# Altium schematic sheet MCU.SchDoc(MCU)
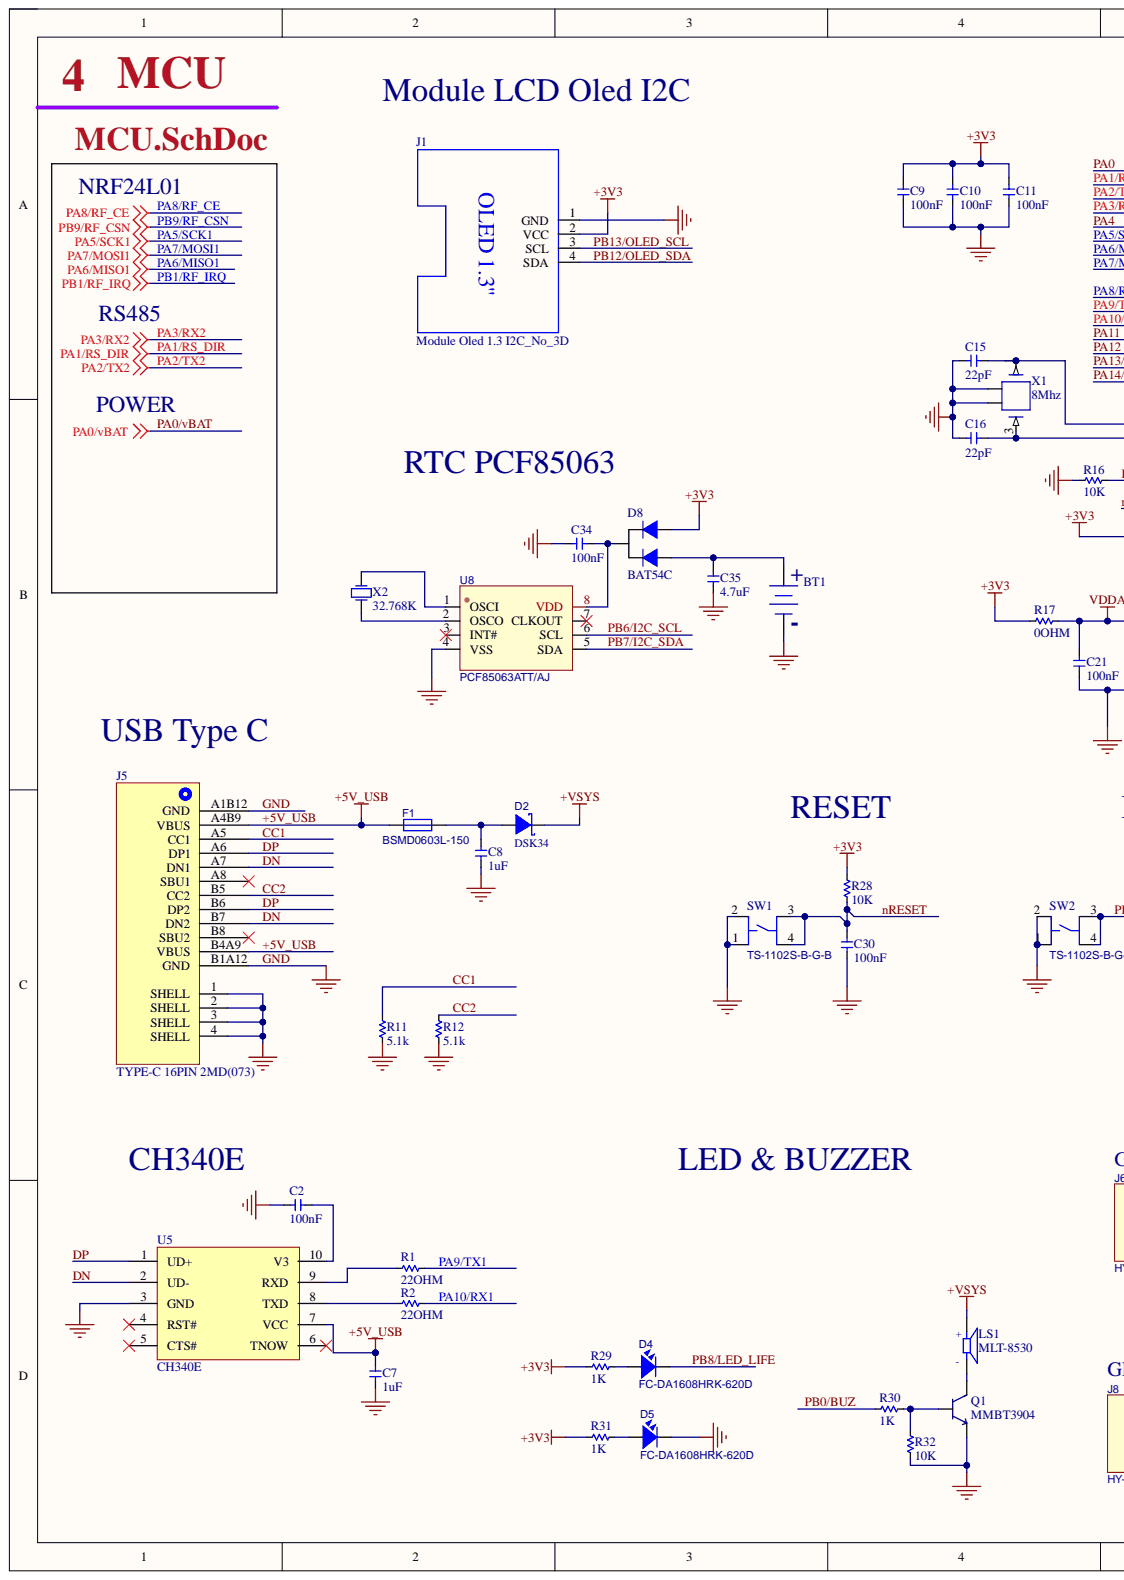
[diagram p0: MCU.SchDoc, left]
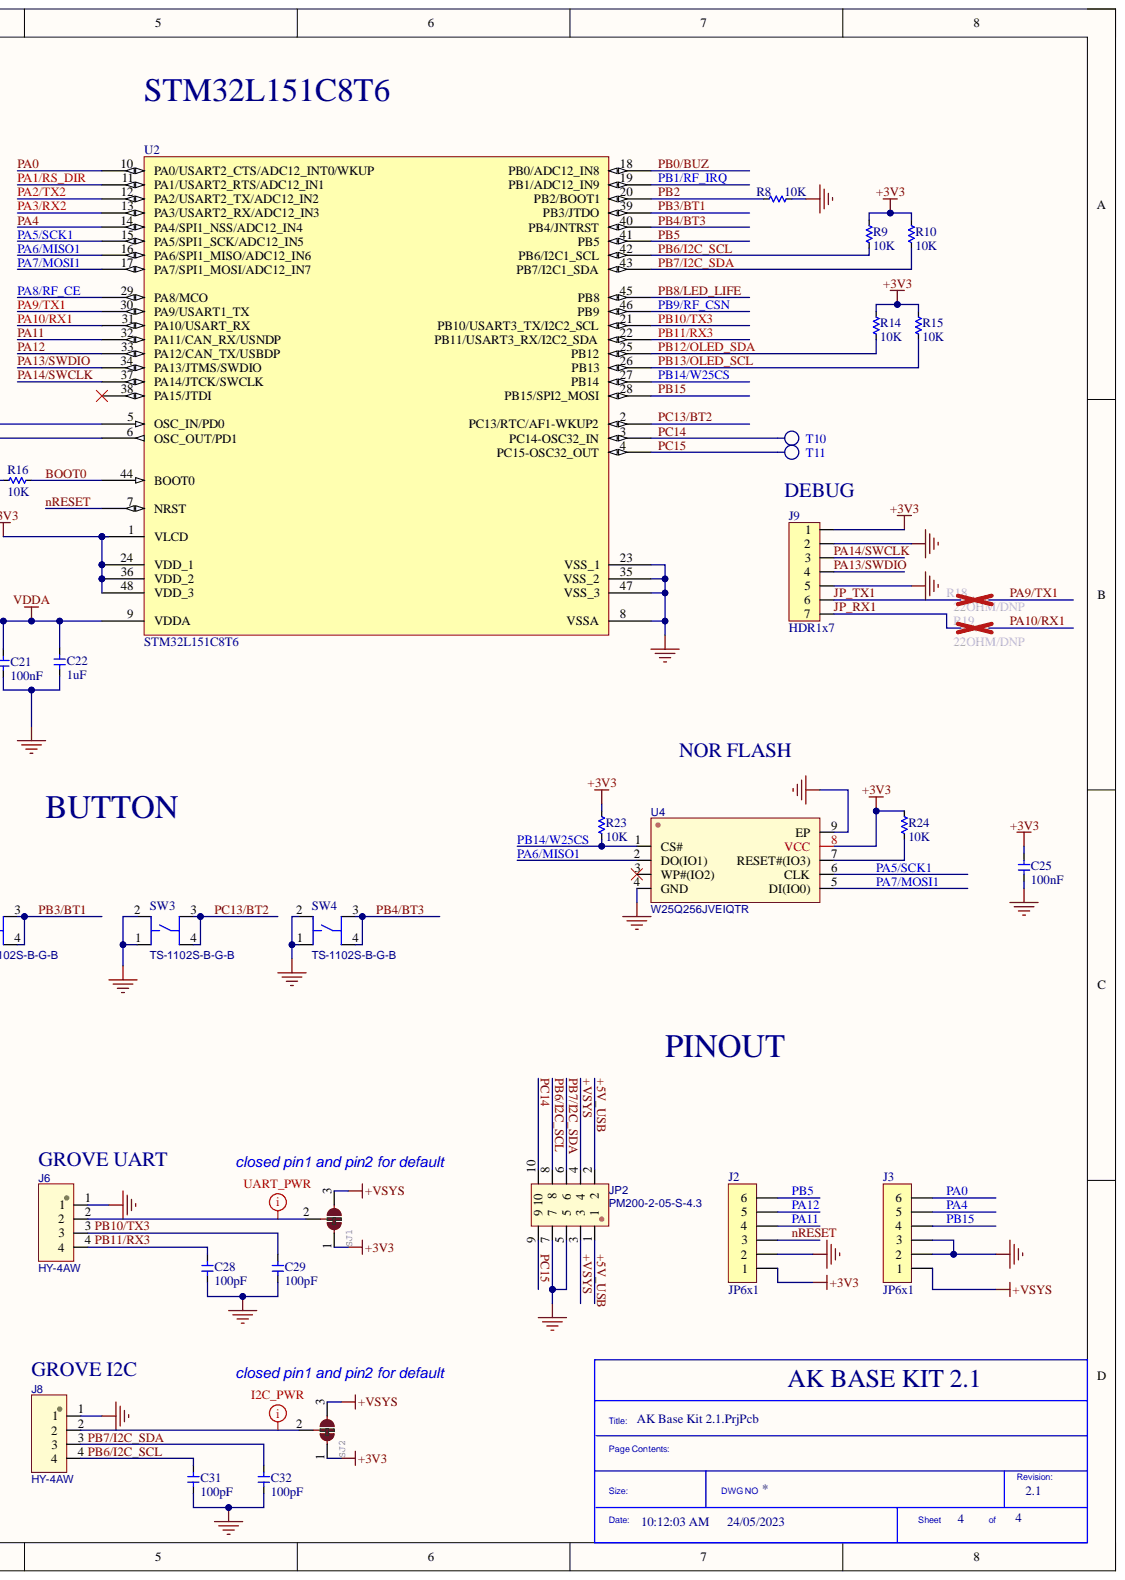
[diagram p1: MCU.SchDoc, right]

## Parts
BT1  MY-1220-03  [Batt CR1220]  (value not drawn)
C2  100nF  [C_0402]
C7  1uF  [C_0603]
C8  1uF  [C_0603]
C9  100nF  [C_0402]
C10  100nF  [C_0402]
C11  100nF  [C_0402]
C15  22pF  [C_0402]
C16  22pF  [C_0402]
C21  100nF  [C_0402]
C22  1uF  [C_0603]
C25  100nF  [C_0402]
C28  100pF  [C_0402]
C29  100pF  [C_0402]
C30  100nF  [C_0402]
C31  100pF  [C_0402]
C32  100pF  [C_0402]
C34  100nF  [C_0402]
C35  4.7uF  [C_0603]
D2  DSK34  [SOD-123_L2.8-W1.8-LS3.7-RD]
D4  FC-DA1608HRK-620D  [LED0603-RD_RED]
D5  FC-DA1608HRK-620D  [LED0603-RD_RED]
D8  BAT54C  [SOT-23-3_L2.9-W1.6-P1.90-LS2.8-BR]
F1  BSMD0603L-150  [F0603]
J1  Module Oled 1.3 I2C_No_3D  [Module Oled 1.3 - No 3D]
J2  JP6x1  [JP6-D1T]  (no BOM)
J3  JP6x1  [JP6-D1T]  (no BOM)
J5  TYPE-C 16PIN 2MD(073)  [USB-C-SMD_TYPE-C16PIN]
J6  HY-4AW  [CONN-TH_4P-P2.00_HY-4AW]
J8  HY-4AW  [CONN-TH_4P-P2.00_HY-4AW]
J9  HDR1x7  [HDR1X7H]
JP2  PM200-2-05-S-4.3  [HDR-SMD_10P-P2.00-V-F-R2-C5-LS6.5]
LS1  MLT-8530  [BUZ-SMD_4P-L8.5-W8.5-P8.50-BR]
Q1  MMBT3904  [SOT-23-3_L2.9-W1.3-P1.90-LS2.4-BR]
R1  22OHM  [R_0402]
R2  22OHM  [R_0402]
R8  10K  [R_0402]
R9  10K  [R_0402]
R10  10K  [R_0402]
R11  5.1k  [R_0402]
R12  5.1k  [R_0402]
R14  10K  [R_0402]
R15  10K  [R_0402]
R16  10K  [R_0402]
R17  0OHM  [R_0603]
R18  22OHM/DNP  [R_0402]  (no BOM)
R19  22OHM/DNP  [R_0402]  (no BOM)
R23  10K  [R_0402]
R24  10K  [R_0402]
R28  10K  [R_0402]
R29  1K  [R_0402]
R30  1K  [R_0402]
R31  1K  [R_0402]
R32  10K  [R_0402]
SJ1  SOLDERJUMPER_2WAY  [SOLDERJUMPER_2WAY]  (value not drawn)  (no BOM)
SJ2  SOLDERJUMPER_2WAY  [SOLDERJUMPER_2WAY]  (value not drawn)  (no BOM)
SW1  TS-1102S-B-G-B  [SW-SMD_4P-L6.0-W6.4-P4.50-LS8.6]
SW2  TS-1102S-B-G-B  [SW-SMD_4P-L6.0-W6.4-P4.50-LS8.6]
SW3  TS-1102S-B-G-B  [SW-SMD_4P-L6.0-W6.4-P4.50-LS8.6]
SW4  TS-1102S-B-G-B  [SW-SMD_4P-L6.0-W6.4-P4.50-LS8.6]
T10  TEST-POINT'4545'  [TP-D45MIL]  (value not drawn)  (no BOM)
T11  TEST-POINT'4545'  [TP-D45MIL]  (value not drawn)  (no BOM)
U2  STM32L151C8T6  [LQFP-48_L7.0-W7.0-P0.50-LS9.0-BL]
U4  W25Q256JVEIQTR  [WSON-8_L8.0-W6.10-P1.27-BL-EP]
U5  CH340E  [MSOP-10_L3.0-W3.0-P0.50-LS5.0-BL]
U8  PCF85063ATT/AJ  [TSSOP-8_L3.0-W3.0-P0.65-LS4.9-BL]
X1  8Mhz  [SMD-3225_4P]
X2  32.768K  [SMD-3215_2P]

## Nets
+3V3: C9.2 C10.2 C11.2 C25.1 D8.2 J1.2(VCC) J2.1 J9.1 R9.1 R10.1 R14.1 R15.1 R17.2 R23.1 R24.1 R28.1 R29.1 R31.1 SJ1.1 SJ2.1 U2.1(VLCD) U2.24(VDD_1) U2.36(VDD_2) U2.48(VDD_3) U4.8(VCC)
VDDA: C21.2 C22.2 R17.1 U2.9(VDDA)
+5V_USB: C7.2 F1.1 J5.A4B9(VBUS) J5.B4A9(VBUS) JP2.1 JP2.2 U5.7(VCC)
+VSYS: D2.1 J3.1 JP2.3 JP2.4 LS1.1 SJ1.3 SJ2.3
BOOT0: R16.2 U2.44(BOOT0)
CC1: J5.A5(CC1) R11.2
CC2: J5.B5(CC2) R12.2
DN: J5.A7(DN1) J5.B7(DN2) U5.2(UD-)
DP: J5.A6(DP1) J5.B6(DP2) U5.1(UD+)
GND: BT1.2 C2.1 C7.1 C8.1 C9.1 C10.1 C11.1 C15.2 C16.2 C21.1 C22.1 C25.2 C28.1 C29.2 C30.1 C31.1 C32.1 C34.2 C35.1 D5.1 J1.1(GND) J2.2 J3.2 J3.3 J5.1(SHELL) J5.2(SHELL) J5.3(SHELL) J5.4(SHELL) J5.A1B12(GND) J5.B1A12(GND) J6.1 J8.1 J9.2 J9.5 JP2.5 JP2.7 Q1.2 R8.1 R11.1 R12.1 R16.1 R32.2 SW1.1 SW1.2 SW2.1 SW2.2 SW3.1 SW3.2 SW4.1 SW4.2 U2.8(VSSA) U2.23(VSS_1) U2.35(VSS_2) U2.47(VSS_3) U4.4(GND) U4.9(EP) U5.3(GND) U8.4(VSS) X1.2 X1.4
JP_RX1: J9.7 R19.2
JP_TX1: J9.6 R18.2
nRESET: C30.2 J2.3 R28.2 SW1.3 SW1.4 U2.7(NRST)
PA0: J3.6 U2.10(PA0/USART2_CTS/ADC12_INT0/WKUP)
PA1/RS_DIR: U2.11(PA1/USART2_RTS/ADC12_IN1)
PA2/TX2: U2.12(PA2/USART2_TX/ADC12_IN2)
PA3/RX2: U2.13(PA3/USART2_RX/ADC12_IN3)
PA4: J3.5 U2.14(PA4/SPI1_NSS/ADC12_IN4)
PA5/SCK1: U2.15(PA5/SPI1_SCK/ADC12_IN5) U4.6(CLK)
PA6/MISO1: U2.16(PA6/SPI1_MISO/ADC12_IN6) U4.2(DO(IO1))
PA7/MOSI1: U2.17(PA7/SPI1_MOSI/ADC12_IN7) U4.5(DI(IO0))
PA8/RF_CE: U2.29(PA8/MCO)
PA9/TX1: R1.1 R18.1 U2.30(PA9/USART1_TX)
PA10/RX1: R2.1 R19.1 U2.31(PA10/USART_RX)
PA11: J2.4 U2.32(PA11/CAN_RX/USNDP)
PA12: J2.5 U2.33(PA12/CAN_TX/USBDP)
PA13/SWDIO: J9.4 U2.34(PA13/JTMS/SWDIO)
PA14/SWCLK: J9.3 U2.37(PA14/JTCK/SWCLK)
PB0/BUZ: R30.1 U2.18(PB0/ADC12_IN8)
PB1/RF_IRQ: U2.19(PB1/ADC12_IN9)
PB2: R8.2 U2.20(PB2/BOOT1)
PB3/BT1: SW2.3 SW2.4 U2.39(PB3/JTDO)
PB4/BT3: SW4.3 SW4.4 U2.40(PB4/JNTRST)
PB5: J2.6 U2.41(PB5)
PB6/I2C_SCL: C31.2 J8.4 JP2.8 R9.2 U2.42(PB6/I2C1_SCL) U8.6(SCL)
PB7/I2C_SDA: C32.2 J8.3 JP2.6 R10.2 U2.43(PB7/I2C1_SDA) U8.5(SDA)
PB8/LED_LIFE: D4.1 U2.45(PB8)
PB9/RF_CSN: U2.46(PB9)
PB10/TX3: C29.1 J6.3 U2.21(PB10/USART3_TX/I2C2_SCL)
PB11/RX3: C28.2 J6.4 U2.22(PB11/USART3_RX/I2C2_SDA)
PB12/OLED_SDA: J1.4(SDA) R14.2 U2.25(PB12)
PB13/OLED_SCL: J1.3(SCL) R15.2 U2.26(PB13)
PB14/W25CS: R23.2 U2.27(PB14) U4.1(CS#)
PB15: J3.4 U2.28(PB15/SPI2_MOSI)
PC13/BT2: SW3.3 SW3.4 U2.2(PC13/RTC/AF1-WKUP2)
PC14: JP2.10 T10.T U2.3(PC14-OSC32_IN)
PC15: JP2.9 T11.T U2.4(PC15-OSC32_OUT)
NetBT1_1: BT1.1 C35.2 D8.1
NetC2_2: C2.2 U5.10(V3)
NetC8_2: C8.2 D2.2 F1.2
NetC15_1: C15.1 U2.5(OSC_IN/PD0) X1.1
NetC16_1: C16.1 U2.6(OSC_OUT/PD1) X1.3
NetC34_1: C34.1 D8.3 U8.8(VDD)
NetD4_2: D4.2 R29.2
NetD5_2: D5.2 R31.2
NetJ6_2: J6.2 SJ1.2
NetJ8_2: J8.2 SJ2.2
NetLS1_2: LS1.2 Q1.3
NetQ1_1: Q1.1 R30.2 R32.1
NetR1_2: R1.2 U5.9(RXD)
NetR2_2: R2.2 U5.8(TXD)
NetR24_2: R24.2 U4.7(RESET#(IO3))
NetU8_1: U8.1(OSCI) X2.2
NetU8_2: U8.2(OSCO) X2.1
PA0/vBAT:

Not connected: J5 A8(SBU1) B8(SBU2); U2 38(PA15/JTDI); U4 3(WP#(IO2)); U5 4(RST#) 5(CTS#) 6(TNOW); U8 3(INT#) 7(CLKOUT)

## Library data of the parts
BT1 = MY-1220-03: MY-1220-03 Battery button CR1220 SMD Battery Connectors ROHS; Manufacturer: MYOUNG; Assembly Type: SMT Assembly; Component Kind: Standard; ComponentLink1Description: https://datasheet.ciiva.com/16220/m60-2p2-16220480.pdf?src-supplier=Future+Electronics; ComponentLink1URL: https://datasheet.ciiva.com/16220/m60-2p2-16220480.pdf?src-supplier=Future+Electronics; ComponentLink2Description: https://datasheet.ciiva.com/18491/1056-18491289.pdf?src-supplier=Digi-Key; ComponentLink2URL: https://datasheet.ciiva.com/18491/1056-18491289.pdf?src-supplier=Digi-Key; ComponentLink3Description: https://datasheet.ciiva.com/26923/getdatasheetpartid-755844-26923850.pdf?src-supplier=Verical; ComponentLink3URL: https://datasheet.ciiva.com/26923/getdatasheetpartid-755844-26923850.pdf?src-supplier=Verical; LCSC Part Number: C964818; Library Name: Dev Board STM OLED 2.1.SCHLIB; Manufacturer Part Number: MY-1220-03; Package: SMD
C2 C9-C11 C21 C25 C30 C34 = 0402B104K160NT: 100nF 16V X7R ±10% 0402 Multilayer Ceramic Capacitors MLCC - SMD/SMT ROH; Manufacturer: FH(Guangdong Fenghua Advanced Tech); Assembly Type: SMT Assembly; Component Kind: Standard; ComponentLink1Description: Digikey Link; ComponentLink2Description: LCSC Link; ComponentLink2URL: https://jlcpcb.com/parts/componentSearch?searchTxt=10k%200603; LCSC Part Number: C41851; Library Name: Dev Board STM OLED 2.0.SCHLIB; Manufacturer Part Number: 0402B104K160NT; Package: 0402
C7 C8 C22 = CL10A105KB8NNNC: 1UF 50V 0603 ROHS; Manufacturer: Samsung Electro-Mechanics; Assembly Type: SMT Assembly; Component Kind: Standard; ComponentLink1Description: Digikey Link; ComponentLink1URL: https://www.digikey.com/en/products/detail/samsung-electro-mechanics/CL10A105KB8NNNC/3887518?s=N4IgTCBcDaIMIBkCMAGAgqgrAaQEIA4A5YuEAXQF8g; ComponentLink2Description: LCSC Link; ComponentLink2URL: https://jlcpcb.com/parts/componentSearch?searchTxt=10k%200603; LCSC Part Number: C15849; Library Name: Dev Board STM OLED 2.0.SCHLIB; Manufacturer Part Number: CL10A105KB8NNNC; Package: 0603
C15 C16 = 0402CG220J500NT: 22pF 50V C0G ±5% 0402 Multilayer Ceramic Capacitors MLCC - SMD/SMT ROHS; Manufacturer: FH(Guangdong Fenghua Advanced Tech); Assembly Type: SMT Assembly; Component Kind: Standard; ComponentLink1Description: Digikey Link; ComponentLink2Description: LCSC Link; ComponentLink2URL: https://jlcpcb.com/parts/componentSearch?searchTxt=10k%200603; LCSC Part Number: C1555; Library Name: Dev Board STM OLED 2.0.SCHLIB; Manufacturer Part Number: 0402CG220J500NT; Package: 0402
C28 C29 C31 C32 = 0402CG101J500NT: 100pF 50V C0G ±5% 0402 Multilayer Ceramic Capacitors MLCC - SMD/SMT ROHS; Manufacturer: FH(Guangdong Fenghua Advanced Tech); Assembly Type: SMT Assembly; Component Kind: Standard; ComponentLink1Description: Digikey Link; ComponentLink2Description: LCSC Link; ComponentLink2URL: https://jlcpcb.com/parts/componentSearch?searchTxt=10k%200603; LCSC Part Number: C1546; Library Name: Dev Board STM OLED 2.0.SCHLIB; Manufacturer Part Number: 0402CG101J500NT; Package: 0402
C35 = CL10A475KO8NNNC: 4.7UF 16V 0603 ROHS; Manufacturer: Samsung Electro-Mechanics; Assembly Type: SMT Assembly; Component Kind: Standard; LCSC Part Number: C19666; Library Name: Dev Board STM OLED 2.1.SCHLIB; Manufacturer Part Number: CL10A475KO8NNNC; Package: 0603
D2 = DSK34: DSK34 40V 550mV@3A 3A SOD-123 Schottky Barrier Diodes (SBD) ROHS Copy; Manufacturer: MDD（Microdiode Electronics); Assembly Type: SMT Assembly; Component Kind: Standard; LCSC Part Number: C41029; Library Name: Dev Board STM OLED 2.1.SCHLIB; Manufacturer Part Number: DSK34; Package: SOD-123
D4 D5 = FC-DA1608HRK-620D: LED Red 0603 Light Emitting Diodes (LED) ROHS; Manufacturer: NATIONSTAR; Assembly Type: SMT Assembly; Component Kind: Standard; LCSC Part Number: C84263; Library Name: Dev Board STM OLED 2.0.SCHLIB; Manufacturer Part Number: FC-DA1608HRK-620D; Package: LED_0603
D8 = BAT54CLT1G: SCHOTTKY BARRIER DIODES (SBD) SOT-23(SOT-23-3) ROHS; Manufacturer: ON Semicon; Assembly Type: SMT Assembly; Component Kind: Standard; LCSC Part Number: C14308; Library Name: Dev Board STM OLED 2.1.SCHLIB; Manufacturer Part Number: BAT54CLT1G; Package: SOT-23-3
F1 = BSMD0603L-150: 6V 1.5A 50A 1W -40℃~+85℃ 3A 5mΩ 500ms 55mΩ 0603; Manufacturer: BHFUSE; Assembly Type: SMT Assembly; Component Kind: Standard; LCSC Part Number: C914094; Library Name: Dev Board STM OLED 2.1.SCHLIB; Manufacturer Part Number: BSMD0603L-150; Package: 0603
J1 = Module Oled 1.3 I2C_No_3D: Module Oled 1.3 I2C; Manufacturer: EPCB.vn; Assembly Type: Hand Soldering; Component Kind: Standard; LCSC Part Number: N/A; Library Name: Dev Board STM OLED 2.1.SCHLIB; Manufacturer Part Number: N/A; Package: Through P=2.54
J2 J3 = JP 6X1 2.54: WR-PHD 2.54 mm Dual Socket Header; Manufacturer: W¨¹rth Elektronik eiSos GmbH; partNo: 61301621821; ComponentLink1 Description: Manufacturer; ComponentLink2 Description: Datasheet; NoPins: 16; ComponentLink1URL: http://www.we-online.com; ComponentLink2URL: http://katalog.we-online.de/em/datasheet/6130xx21821.pdf; Created Date: 29 March 2013; Library Name: Dev Board STM OLED 2.0.SCHLIB; Created by: Karrer Zheng
J5 = TYPE-C 16PIN 2MD(073): 5A 1 Surface Mount 16 Female -25℃~+85℃ Type-C SMD USB Connectors ROHS; Manufacturer: SHOU HAN; Assembly Type: SMT Assembly; Component Kind: Standard; LCSC Part Number: C2765186; Library Name: Dev Board STM OLED 2.0.SCHLIB; Manufacturer Part Number: TYPE-C16PIN; Package: SMD
J6 J8 = HY-4AW: HY-4AW 1x4P 1 2mm 4 Push-Pull,P=2mm Wire To Board / Wire To Wire Connector ROHS; Manufacturer: BOOMELE; Pakage: 弯插,P=2mm; Assembly Type: Hand Soldering; Component Kind: Standard; LCSC Part Number: C146068; Library Name: Dev Board STM OLED 2.0.SchLib; Manufacturer Part Number: HY-4AW
J9 = Header 1x7: Header IDC, 1x8 Pin horizontal 2.54mm Pitch (Non-Boxed, Male); Manufacturer Part Number: HDR1x7 Horizonal; ComponentLink1 Description: Manufacturer; ComponentLink2 Description: Datasheet; Assembly Type: Hand Soldering; Component Kind: Standard; ComponentLink1URL: http://www.we-online.com; ComponentLink2URL: http://katalog.we-online.de/em/datasheet/6130xx143121.pdf; Library Name: Dev Board STM OLED 2.0.SCHLIB; Package: P=2.54
JP2 = PM200-2-05-S-4.3: SMD,P=2mm Female Headers ROHS; Manufacturer: HCTL(华灿天禄); Assembly Type: SMT Assembly; Component Kind: Standard; LCSC Part Number: C3975169; Library Name: Dev Board STM OLED 2.1.SCHLIB; Manufacturer Part Number: PM200-2-05-S-4.3; Package: SMD, P=2mm
LS1 = BUZ_MLT-8530: BUZZERS MAGNETIC NO 2700HZ 3.6V 2.5 ~ 4.5V 8.5MM X 8.5MM 8.5*8.5*3MM ROHS; Manufacturer: Jiangsu Huaneng Elec; Assembly Type: SMT Assembly; Component Kind: Standard; LCSC Part Number: C94599; Library Name: Dev Board STM OLED 2.0.SCHLIB; Manufacturer Part Number: MLT-8530; Package: SMD-4_8.7x8.7x3.0
Q1 = MMBT3904: MMBT3904 TRANSISTORS (NPN/PNP) NPN 200MA 40V SOT-23(SOT-23-3) ROHS; Manufacturer: Changjiang Electronics Tech (CJ); Assembly Type: SMT Assembly; Component Kind: Standard; LCSC Part Number: C20526; Library Name: Dev Board STM OLED 2.0.SCHLIB; Manufacturer Part Number: MMBT3904; Package: SOT-23-3
R1 R2 R18 R19 = 0402WGF220JTCE: 22Ω 0402 62.5mW Thick Film Resistors 50V ±100ppm/℃ ±1% -55℃~+155℃; Manufacturer: Uniroyal Elec; Assembly Type: SMT Assembly; LCSC Part Number: C25092; Library Name: Dev Board STM OLED 2.0.SCHLIB; Manufacturer Part Number: 0402WGF220JTCE; Package: 0402
  R1 R2: Component Kind: Standard
R8-R10 R14-R16 R23 R24 R28 R32 = 0402WGF1002TCE: 10kΩ 0402 62.5mW Thick Film Resistors 50V ±100ppm/℃ ±1% -55℃~+155℃; Manufacturer: Uniroyal Elec; Assembly Type: SMT Assembly; Component Kind: Standard; LCSC Part Number: C25744; Library Name: Dev Board STM OLED 2.0.SchLib; Manufacturer Part Number: 0402WGF1002TCE; Package: 0402
R11 R12 = 0402WGJ0512TCE: 5.1KOHMS ±5% 1/16W 0402 ROHS; Manufacturer: Uniroyal Elec; Assembly Type: SMT Assembly; Component Kind: Standard; LCSC Part Number: C25941; Library Name: Dev Board STM OLED 2.0.SchLib; Manufacturer Part Number: 0402WGJ0512TCE; Package: 0402
R17 = 0603WAF0000T5E: 0OHMS ±1% 1/10W 0603 ROHS; Manufacturer: Uniroyal Elec; Assembly Type: SMT Assembly; Component Kind: Standard; LCSC Part Number: C21189; Library Name: Dev Board STM OLED 2.0.SCHLIB; Manufacturer Part Number: 0603WAF0000T5E; Package: 0603
R29-R31 = 0402WGF1001TCE: 1kΩ 0402 62.5mW Thick Film Resistors 50V ±100ppm/℃ ±1% -55℃~+155℃; Manufacturer: Uniroyal Elec; Assembly Type: SMT Assembly; Component Kind: Standard; LCSC Part Number: C11702; Library Name: Dev Board STM OLED 2.0.SchLib; Manufacturer Part Number: 0402WGF1001TCE; Package: 0402
SJ1 SJ2 = SOLDERJUMPER_2WAY: 2-Way Solder Jumper; GateName_1: G$1; DeviceSetName: SOLDERJUMPER_2WAY; Library Name: Dev Board STM OLED 2.0.SCHLIB; LibraryName: microbuilder; SymbolName_1: SOLDERJUMPER_2WAY
SW1-SW4 = TS-1102S-B-G-B: Botton SMD TACTILE SWITCHES ROHS; Manufacturer: XKB Connectivity; Manufacturer Part Number: TS-1102S-C-F-B; Assembly Type: SMT Assembly; Component Kind: Standard; LCSC Part Number: C381064; Library Name: Dev Board STM OLED 2.0.SCHLIB; Package: SMD-4_6.0x6.0x4.5P-2
T10 T11 = TEST-POINT'4545': Manufacturer: No; Description: TEST-POINT'4545'; LCSC Part Number: No; Library Name: Dev Board STM OLED 2.0.SchLib; Manufacturer Part Number: No; Package: No
U2 = STM32L151C8T6: STM32 ARM-based 32-bit MCU with 64 Kbytes Flash, 48-pin LQFP, Industrial Temperature; Manufacturer: STMicroelectronics; Assembly Type: SMT Assembly; Component Kind: Standard; ComponentLink1Description: Manufacturer Link; ComponentLink1URL: www.st.com/stm32; ComponentLink2Description: Datasheet; ComponentLink2URL: http://www.st.com/stonline/products/literature/ds/13587.pdf; ComponentLink3Description: https://datasheet.ciiva.com/3220/lm2734-3220071.pdf?src-supplier=Avnet; ComponentLink3URL: https://datasheet.ciiva.com/3220/lm2734-3220071.pdf?src-supplier=Avnet; ComponentLink4Description: https://datasheet.ciiva.com/3220/lm2734-3220072.pdf?src-supplier=Avnet; ComponentLink4URL: https://datasheet.ciiva.com/3220/lm2734-3220072.pdf?src-supplier=Avnet; ComponentLink5Description: https://datasheet.ciiva.com/6598/lm2734-6598009.pdf?src-supplier=Digi-Key; ComponentLink5URL: https://datasheet.ciiva.com/6598/lm2734-6598009.pdf?src-supplier=Digi-Key; ComponentLink6Description: https://datasheet.ciiva.com/16536/lm2734-16536154.pdf?src-supplier=Avnet+Asia; ComponentLink6URL: https://datasheet.ciiva.com/16536/lm2734-16536154.pdf?src-supplier=Avnet+Asia; ComponentLink7Description: New link; LCSC Part Number: C9861; Library Name: Dev Board STM OLED 2.1.SCHLIB; Manufacturer Part Number: STM32L151C8T6; Package: LQFP-48_7.0x7.0x0.5P Copy
U4 = W25Q256JVEIQTR: W25Q256 WSON-8_8x6mm NOR FLASH ROHS; Manufacturer: Winbond Elec; Assembly Type: SMT Assembly; Component Kind: Standard; LCSC Part Number: C97522; Library Name: Dev Board STM OLED 2.1.SCHLIB; Manufacturer Part Number: W25Q256JVEIQTR; Package: WSON-8_8x6mm
U5 = CH340E: Transceiver USB 2.0 2Mbps MSOP-10 USB ICs RoHS; Manufacturer: WCH(Jiangsu Qin Heng); Assembly Type: SMT Assembly; Component Kind: Standard; LCSC Part: C99652; Library Name: Dev Board STM OLED 2.0.SCHLIB; Manufacturer Part: CH340E; Package: MSOP-10
U8 = PCF85063ATT/AJ: TSSOP-8-3.0mm Real-time Clocks (RTC) ROHS; Manufacturer: NXP; Assembly Type: AMT Assembly; Component Kind: Standard; LCSC Part Number: C165608; Library Name: AK Base Kit 2.1.SCHLIB; Manufacturer Part Number: PCF85063ATT/AJ; Package: TSSOP-8-3.0mm
X1 = 1C208000CE0Q: 8Mhz 3225 SMD CRYSTAL RESONATORS 8MHZ SMD-3225-4P ROHS; Manufacturer: KDS Daishinku; Assembly Type: SMT Assembly; Component Kind: Standard; LCSC Part Number: C133366; Library Name: Dev Board STM OLED 2.0.SCHLIB; Manufacturer Part Number: 1C208000CE0Q; Package: SMD-3225_4P
X2 = XTAL_32KHZ_3215: 32.768K SMD CRYSTAL RESONATORS 32.768KHZ ±20PPM SMD-3215_2P ROHS; Manufacturer: Seiko Epson; Manufacturer Part Number: Q13FC1350000400; Assembly Type: SMT Assembly; Component Kind: Standard; LCSC Part Number: C32346; Library Name: Dev Board STM OLED 2.0.SCHLIB; Package: SMD-3215_2P
